# Altium schematic sheet Level Shifters.SchDoc
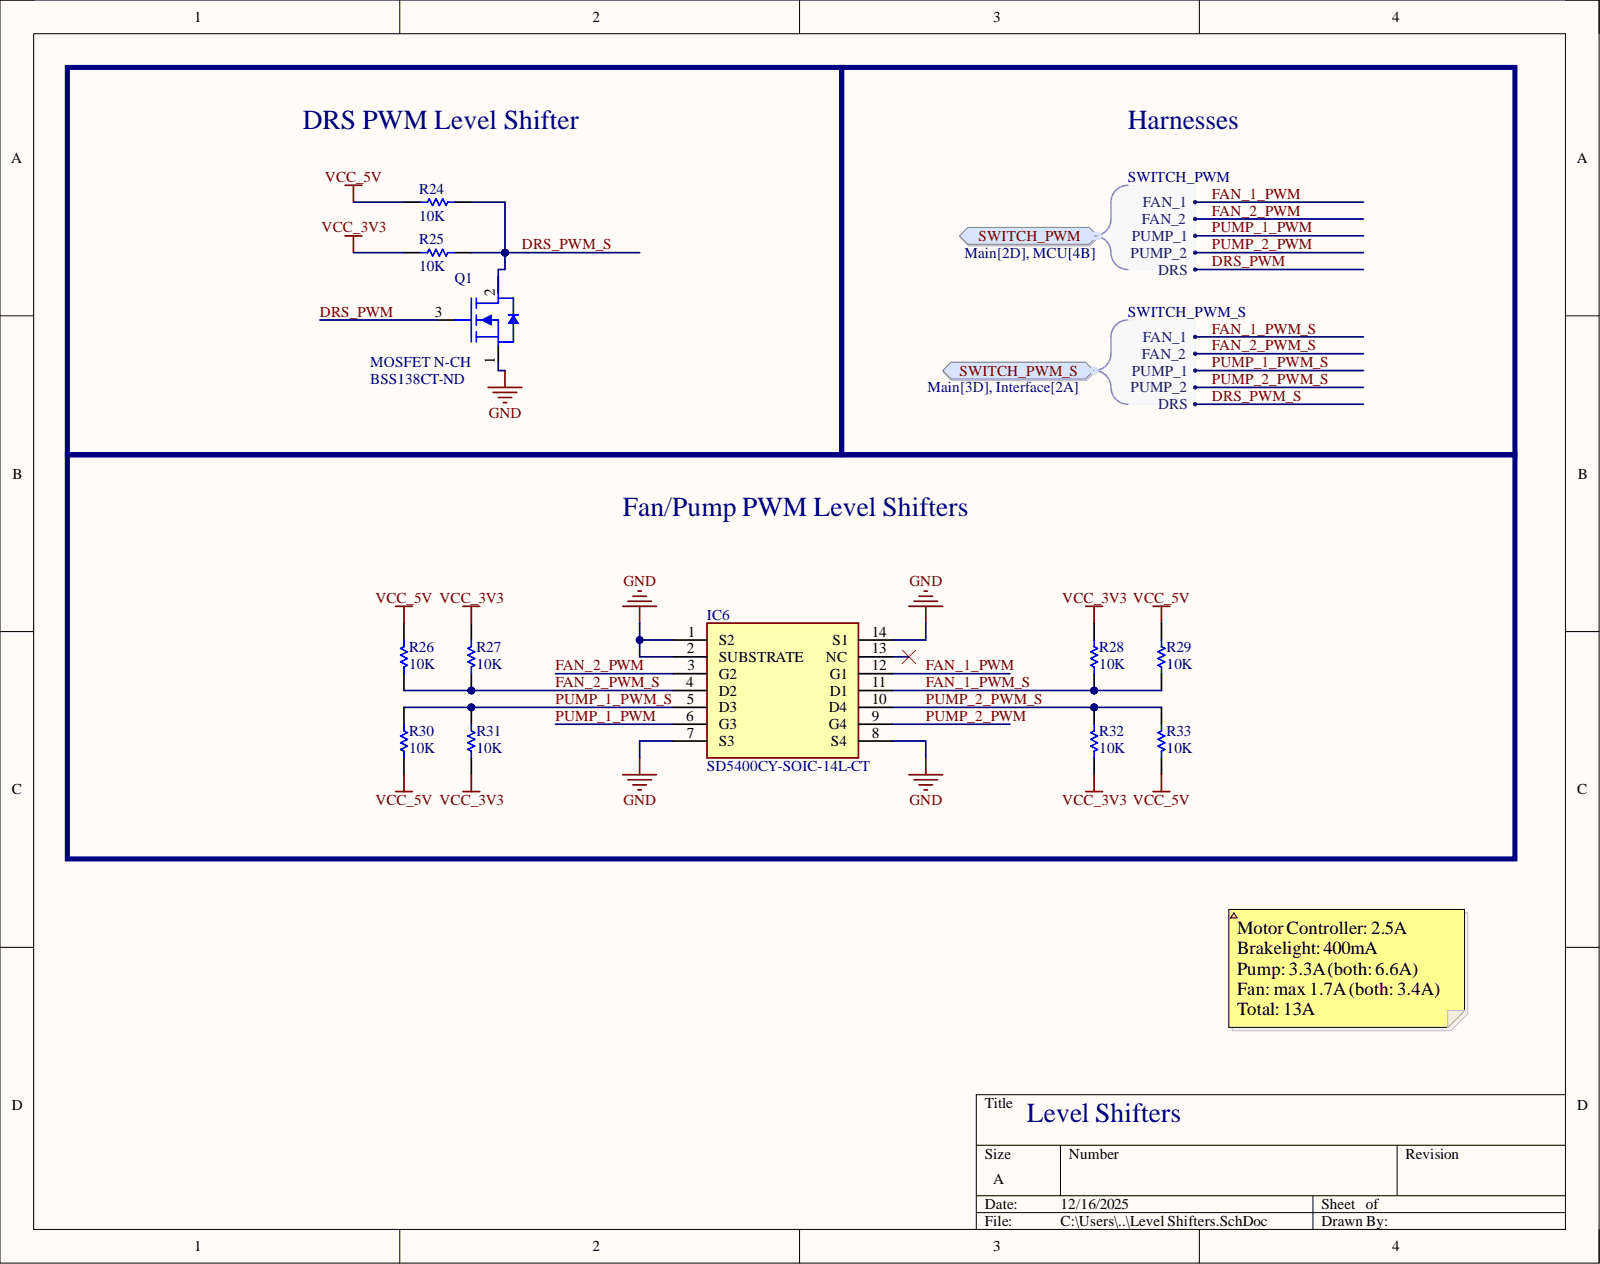
[diagram p0: Level Shifters.SchDoc]

## Parts
IC6  SD5400CY-SOIC-14L-CT  [SOIC127P600X175-14N]
Q1  MOSFET N-CH  Supplier Part Number 1: BSS138CT-ND  [SOT90P240X110-3N]
R24  10K  [RES_SM0603_YAGEORC_N]
R25  10K  [RES_SM0603_YAGEORC_N]
R26  10K  [RES_SM0603_YAGEORC_N]
R27  10K  [RES_SM0603_YAGEORC_N]
R28  10K  [RES_SM0603_YAGEORC_N]
R29  10K  [RES_SM0603_YAGEORC_N]
R30  10K  [RES_SM0603_YAGEORC_N]
R31  10K  [RES_SM0603_YAGEORC_N]
R32  10K  [RES_SM0603_YAGEORC_N]
R33  10K  [RES_SM0603_YAGEORC_N]

## Ports
SWITCH_PWM, SWITCH_PWM.DRS, SWITCH_PWM.FAN_1, SWITCH_PWM.FAN_2, SWITCH_PWM.PUMP_1, SWITCH_PWM.PUMP_2, SWITCH_PWM_S, SWITCH_PWM_S.DRS, SWITCH_PWM_S.FAN_1, SWITCH_PWM_S.FAN_2, SWITCH_PWM_S.PUMP_1, SWITCH_PWM_S.PUMP_2

## Nets
GND: IC6.1(S2) IC6.2(SUBSTRATE) IC6.7(S3) IC6.8(S4) IC6.14(S1) Q1.1
VCC_3V3: R25.1 R27.2 R28.2 R31.2 R32.2
VCC_5V: R24.1 R26.2 R29.2 R30.2 R33.2
DRS_PWM: Q1.3 ; port SWITCH_PWM
DRS_PWM_S: Q1.2 R24.2 R25.2 ; port SWITCH_PWM_S
FAN_1_PWM: IC6.12(G1) ; port SWITCH_PWM
FAN_1_PWM_S: IC6.11(D1) R28.1 R29.1 ; port SWITCH_PWM_S
FAN_2_PWM: IC6.3(G2) ; port SWITCH_PWM
FAN_2_PWM_S: IC6.4(D2) R26.1 R27.1 ; port SWITCH_PWM_S
PUMP_1_PWM: IC6.6(G3) ; port SWITCH_PWM
PUMP_1_PWM_S: IC6.5(D3) R30.1 R31.1 ; port SWITCH_PWM_S
PUMP_2_PWM: IC6.9(G4) ; port SWITCH_PWM
PUMP_2_PWM_S: IC6.10(D4) R32.1 R33.1 ; port SWITCH_PWM_S
NetIC6_13: IC6.13(NC)

## Library data of the parts
IC6 = SD5400CY-SOIC-14L-CT: Integrated Circuit; Manufacturer_Name: Linear Integrated Systems; Component Kind: Standard; Datasheet Link: https://www.mouser.sg/datasheet/2/1442/SD5000SeriesDSRevA12-3180024.pdf; Height: 1.75mm; Library Name: Misc.IntLib; Manufacturer_Part_Number: SD5400CY-SOIC-14L-CT; Mouser Part Number: 722-D5400CYSOIC14LCT; Mouser Price/Stock: https://www.mouser.co.uk/ProductDetail/Linear-Integrated-Systems/SD5400CY-SOIC-14L-CT?qs=T%252BzbugeAwjiENB9uQnJ51Q%3D%3D; Supplier 1: Mouser; Supplier Part Number 1: 722-D5400CYSOIC14LCT
Q1 = BSS138 Fairchild: N-Channel 220mA (Ta) 360mW (Ta) Surface Mount SOT-23-3; Component Kind: Standard; Library Name: Misc.IntLib; Supplier 1: Digi-Key
R24-R33 = RC0603FR-0710KL: RES 10K SM0603 YAGEO RC_L; Power (Watts): 0.1; Resistance (Ohms): 10000; Tolerance (%): 1; Category: Resistor; Component Kind: Standard; ComponentLink1Description: Manufacturer Website; ComponentLink1URL: http://www.yageo.com; Library Name: ResistorCapsInductors.IntLib; Manufacturer: Yageo; Manufacturer Part Number: RC0603FR-0710KL; Package: 0603; Supplier 1: Farnell; Supplier 2: Digi-Key; Supplier Part Number 1: 9238603; Supplier Part Number 2: 311-10.0KHRCT-ND; Value: 10K
